# Altium schematic sheet RPi-CAM-LCD.SchDoc(RPi-CAM-LCD)
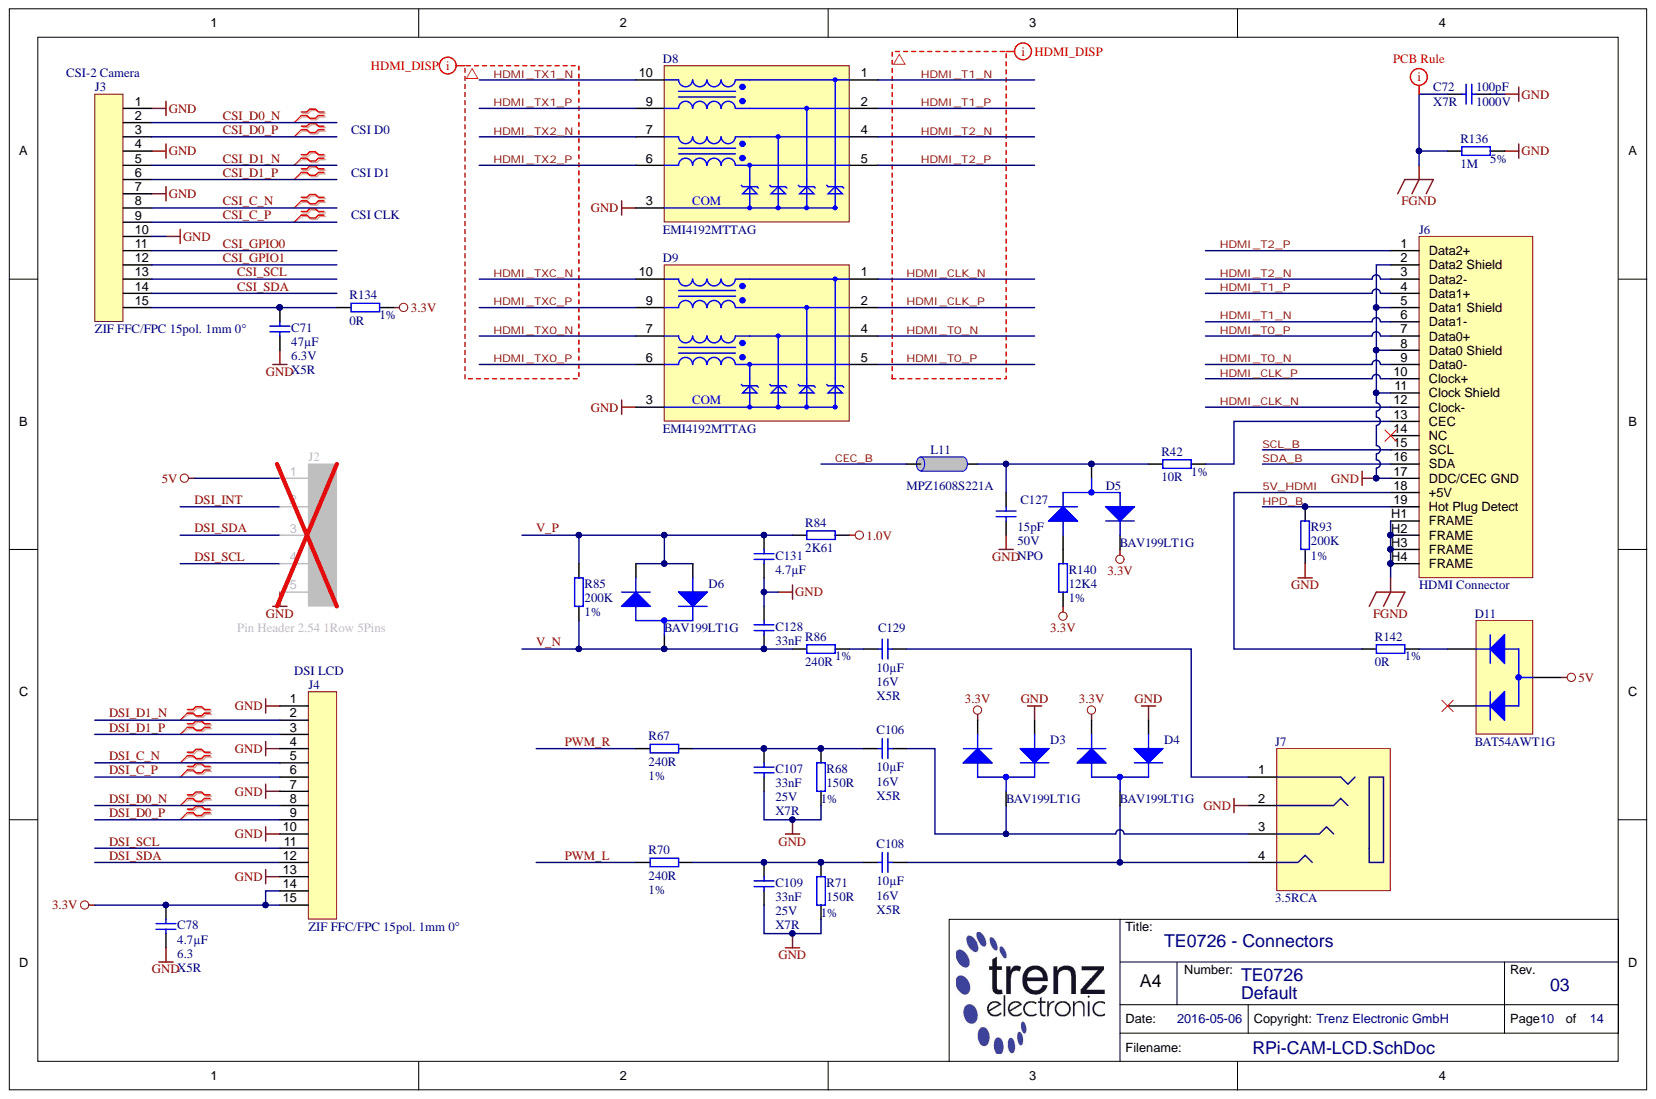
[diagram p0: RPi-CAM-LCD.SchDoc]

## Parts
C71  47µF  Technology: X5R  Voltage: 6.3V  [CAPC2012X135N]
C72  100pF  Technology: 1000V  Voltage: X7R  [CAPC3216X180N]
C78  4.7µF  Technology: X5R  Voltage: 6.3  [CAPC1005X60N]
C106  10µF  Voltage: 16V  [CAPC1608X90N]
C107  33nF  Technology: X7R  Voltage: 25V  [CAPC1005X55N]
C108  10µF  Voltage: 16V  [CAPC1608X90N]
C109  33nF  Technology: X7R  Voltage: 25V  [CAPC1005X55N]
C127  15pF  Voltage: 50V  [CAPC1005X55N]
C128  33nF  [CAPC1005X55N]
C129  10µF  Voltage: 16V  [CAPC1608X90N]
C131  4.7µF  [CAPC1005X60N]
D3  BAV199LT1G  [SOT95P230X109-3N]
D4  BAV199LT1G  [SOT95P230X109-3N]
D5  BAV199LT1G  [SOT95P230X109-3N]
D6  BAV199LT1G  [SOT95P230X109-3N]
D8  EMI4192MTTAG  [EMI4192]
D9  EMI4192MTTAG  [EMI4192]
D11  BAT54AWT1G  [SOT65P210X110-3N]
J2  Pin Header 2.54 1Row 5Pins  [STIFTLEISTE2_54 OG 1R-5]
J3  ZIF FFC/FPC 15pol. 1mm 0°  [5562-15-1]
J4  ZIF FFC/FPC 15pol. 1mm 0°  [5562-15-1]
J6  HDMI Connector  Case: HDMI  [A35071TR-ND]
J7  3.5RCA  [MJ-2135]
L11  MPZ1608S221A  [INDC1608X95N]
R42  10R  Tolerance: 1%  [RESC1005X40N]
R67  240R  Tolerance: 1%  [RESC1005X40N]
R68  150R  Tolerance: 1%  [RESC1005X40N]
R70  240R  Tolerance: 1%  [RESC1005X40N]
R71  150R  Tolerance: 1%  [RESC1005X40N]
R84  2K61  [RESC1005X40N]
R85  200K  Tolerance: 1%  [RESC1005X40N]
R86  240R  Tolerance: 1%  [RESC1005X40N]
R93  200K  Tolerance: 1%  [RESC1005X40N]
R134  0R  Tolerance: 1%  [RESC1608X55N]
R136  1M  Tolerance: 5%  [RESC3216X65N]
R140  12K4  Tolerance: 1%  [RESC1005X40N]
R142  0R  Tolerance: 1%  [RESC1608X55N]

## Nets
1.0V: R84.2
3.3V: C78.2 D3.2 D4.2 D5.2 J4.14 J4.15 R134.2 R140.1
5V: D11.3 J2.1
FGND: C72.1 J6.H1(FRAME) J6.H2(FRAME) J6.H3(FRAME) J6.H4(FRAME) R136.1
GND: C71.2 C72.2 C78.1 C107.2 C109.2 C127.2 C128.2 C131.2 D3.1 D4.1 D8.3 D9.3 J2.5 J3.1 J3.4 J3.7 J3.10 J4.1 J4.4 J4.7 J4.10 J4.13 J6.2(Data2_Shield) J6.5(Data1_Shield) J6.8(Data0_Shield) J6.11(Clock_Shield) J6.17(DDC/CEC_GND) J7.2 R68.2 R71.2 R93.1 R136.2
5V_HDMI: J6.18(+5V) R142.1
CEC_B: L11.2
CSI_C_N: J3.8
CSI_C_P: J3.9
CSI_D0_N: J3.2
CSI_D0_P: J3.3
CSI_D1_N: J3.5
CSI_D1_P: J3.6
CSI_GPIO0: J3.11
CSI_GPIO1: J3.12
CSI_SCL: J3.13
CSI_SDA: J3.14
DSI_C_N: J4.5
DSI_C_P: J4.6
DSI_D0_N: J4.8
DSI_D0_P: J4.9
DSI_D1_N: J4.2
DSI_D1_P: J4.3
DSI_INT: J2.2
DSI_SCL: J2.4 J4.11
DSI_SDA: J2.3 J4.12
HDMI_CLK_N: D9.1 J6.12(Clock-)
HDMI_CLK_P: D9.2 J6.10(Clock+)
HDMI_T0_N: D9.4 J6.9(Data0-)
HDMI_T0_P: D9.5 J6.7(Data0+)
HDMI_T1_N: D8.1 J6.6(Data1-)
HDMI_T1_P: D8.2 J6.4(Data1+)
HDMI_T2_N: D8.4 J6.3(Data2-)
HDMI_T2_P: D8.5 J6.1(Data2+)
HDMI_TX0_N: D9.7
HDMI_TX0_P: D9.6
HDMI_TX1_N: D8.10
HDMI_TX1_P: D8.9
HDMI_TX2_N: D8.7
HDMI_TX2_P: D8.6
HDMI_TXC_N: D9.10
HDMI_TXC_P: D9.9
HPD_B: J6.19(Hot_Plug_Detect) R93.2
PWM_L: R70.1
PWM_R: R67.1
SCL_B: J6.15(SCL)
SDA_B: J6.16(SDA)
V_N: C128.1 D6.3 R85.1 R86.1
V_P: C131.1 D6.1 D6.2 R84.1 R85.2
NetC71_1: C71.1 J3.15 R134.1
NetC106_1: C106.1 D3.3 J7.3
NetC106_2: C106.2 C107.1 R67.2 R68.1
NetC108_1: C108.1 D4.3 J7.4
NetC108_2: C108.2 C109.1 R70.2 R71.1
NetC127_1: C127.1 D5.3 L11.1 R42.1
NetC129_1: C129.1 J7.1
NetC129_2: C129.2 R86.2
NetD5_1: D5.1 R140.2
NetD11_2: D11.2 R142.2
NetJ6_13: J6.13(CEC) R42.2
N00457:
N00458:

Not connected: D11 1; J6 14(NC)

## Library data of the parts
C71 = 24718: KON 47µF 6,3V  X5R SMD 20%; Database Table Name: C_2012_0805; Mixed: SMT; Preferred: Yes; Value: 47u; AN: 24718; Case: 2012 (0805); Component Kind: Standard; Library Name: TE.DbLib; Tolerance: 20%
C72 = 22679: KON 100pF 1000V X7R 10%; Database Table Name: C_3216_1206; Mixed: SMT; Preferred: Yes; Value: 100p; AN: 22679; Case: 3216 (1206); Component Kind: Standard; Library Name: TE.DbLib; Tolerance: 10%
C78 = 24719: KON 4.7µF 6.3V X5R SMD 20%; Database Table Name: C_1005_0402; Mixed: SMT; Preferred: Yes; Value: 4.7u; AN: 24719; Case: 1005 (0402); Component Kind: Standard; Library Name: TE.DbLib; Tolerance: 20%
C106 C108 C129 = 25341: KON 10µF 16V X5R SMD 20%; Database Table Name: C_1608_0603; Mixed: SMT; Preferred: Yes; Value: 10u; AN: 25341; Case: 1608 (0603); Component Kind: Standard; Library Name: TE.DbLib; Technology: X5R; Tolerance: 20%
C107 C109 C128 = 23916: KON 33nF 25V X7R SMD; Database Table Name: C_1005_0402; Mixed: SMT; Preferred: Yes; Value: 33n; AN: 23916; Case: 1005 (0402); Component Kind: Standard; Library Name: TE.DbLib
  C128: Technology: X7R; Voltage: 25V
C127 = 23951: KON 15pF NPO 50V SMD; Database Table Name: C_1005_0402; Mixed: SMT; Preferred: Yes; Value: 15p; AN: 23951; Case: 1005 (0402); Component Kind: Standard; Library Name: TE.DbLib; Technology: NPO
C131 = 24719: KON 4.7µF 6.3V X5R SMD 20%; Database Table Name: C_1005_0402; Mixed: SMT; Preferred: Yes; Value: 4.7u; Voltage: 6.3; AN: 24719; Case: 1005 (0402); Component Kind: Standard; Library Name: TE.DbLib; Technology: X5R; Tolerance: 20%
D3-D6 = 25184: Database Table Name: DIODE; Mixed: SMT; Preferred: Yes; AN: 25184; Case: SOT23-3; Component Kind: Standard; Description: BAV199LT1G; H-ART: BAV199LT1G; Library Name: TE.DbLib; Trenz-Stock: Yes; HelpURL: B:\AD\adlib\datasheet\BAV199LT1G
D8 D9 = 27126: Database Table Name: DIODE; Mixed: SMT; AN: 27126; Case: WDFN-10; Component Kind: Standard; Description: EMI4192MTTAG; H-ART: EMI4192MTTAG; Library Name: TE.DbLib; HelpURL: B:\ad\adlib\datasheet\EMI4192
D11 = 26805: Database Table Name: DIODE; Mixed: SMT; AN: 26805; Case: SOT323; Component Kind: Standard; Description: BAT54AWT1G; H-ART: BAT54AWT1G; Library Name: TE.DbLib; HelpURL: B:\ad\adlib\datasheet\BAT54AW
J2 = 25890: Stiftleiste gerade 1-reihig, 5pol.; Database Table Name: CON_HEADER; Mixed: THT; Trenz-Stock: Yes; AN: 25890; Component Kind: Standard; Library Name: TE.DbLib
J3 J4 = 27289: ZIF FFC/FPC Connector 15pol 1mm 0°; Database Table Name: CON_IO; H-ART: 5562-15-1-ST; Mixed: SMT; AN: 27289; Component Kind: Standard; Library Name: TE.DbLib; HelpURL: C:\SVNDaten\Brose\AD\adlib\datasheet\5562
J6 = 26642: HDMI Buchse 19Pol. 90°; Database Table Name: CON_IO; H-ART: 685119134923; Mixed: SMT; Trenz-Stock: Yes; AN: 26642; Component Kind: Standard; Library Name: TE.DbLib; HelpURL: B:\AD\adlib\datasheet\685119134923
J7 = 26290: 3.5mm Earphone Jack; Database Table Name: CON_IO; Mixed: THT; AN: 26290; Component Kind: Standard; H-ART: MJ-2135; Library Name: TE.DbLib; HelpURL: B:\AD\adlib\datasheet\1672224
L11 = 22718: Ferritdurchführung MPZ1608S221A; Database Table Name: L_1608_0603; Mixed: SMT; Preferred: Yes; Value: 220 OHm @ 100MHz; AN: 22718; Case: 1608 (0603); Component Kind: Standard; H-ART: MPZ1608S221A; Library Name: TE.DbLib; Trenz-Stock: Yes; HelpURL: B:\AD\adlib\datasheet\MPZ1608
R42 = 22844: WID SMD 10R 1% 0,063W; Database Table Name: R_1005_0402; Mixed: SMT; Preferred: Yes; Value: 10; AN: 22844; Case: 1005 (0402); Component Kind: Standard; Library Name: TE.DbLib; Technology: TK100
R67 R70 R86 = 23661: WID SMD 240R 1% 0,063W; Database Table Name: R_1005_0402; Mixed: SMT; Preferred: Yes; Value: 240; AN: 23661; Case: 1005 (0402); Component Kind: Standard; Library Name: TE.DbLib; Technology: TK100
R68 R71 = 22766: WID SMD 150R 1% 0,063W; Database Table Name: R_1005_0402; Mixed: SMT; Preferred: Yes; Value: 150; AN: 22766; Case: 1005 (0402); Component Kind: Standard; Library Name: TE.DbLib; Technology: TK100
R84 = 23730: WID SMD 2K61 1% 0,063W; Database Table Name: R_1005_0402; Mixed: SMT; Preferred: Yes; Value: 2.61K; AN: 23730; Case: 1005 (0402); Component Kind: Standard; Library Name: TE.DbLib; Technology: TK100; Tolerance: 1%
R85 R93 = 23846: WID SMD 200K 1% 0,063W; Database Table Name: R_1005_0402; Mixed: SMT; Preferred: Yes; AN: 23846; Case: 1005 (0402); Component Kind: Standard; Library Name: TE.DbLib; Technology: TK100; Value: 200K
R134 R142 = 21491: WID SMD 0R 0,1W 1% TK100; Database Table Name: R_1608_0603; Mixed: SMT; Value: 0; AN: 21491; Case: 1608 (0603); Component Kind: Standard; Library Name: TE.DbLib; Technology: TK100
R136 = 22757: WID SMD 1M 5% 0,25W; Database Table Name: R_3216_1206; Mixed: SMT; Preferred: Yes; AN: 22757; Case: 3216 (1206); Component Kind: Standard; Library Name: TE.DbLib; Technology: TK100; Value: 1M
R140 = 27133: WID SMD 12K4 1% 0,063W; Database Table Name: R_1005_0402; Mixed: SMT; Preferred: Yes; Value: 12.4K; AN: 27133; Case: 1005 (0402); Component Kind: Standard; Library Name: TE.DbLib; Technology: TK100
